# Altium schematic sheet photodiode-amplifier.SchDoc
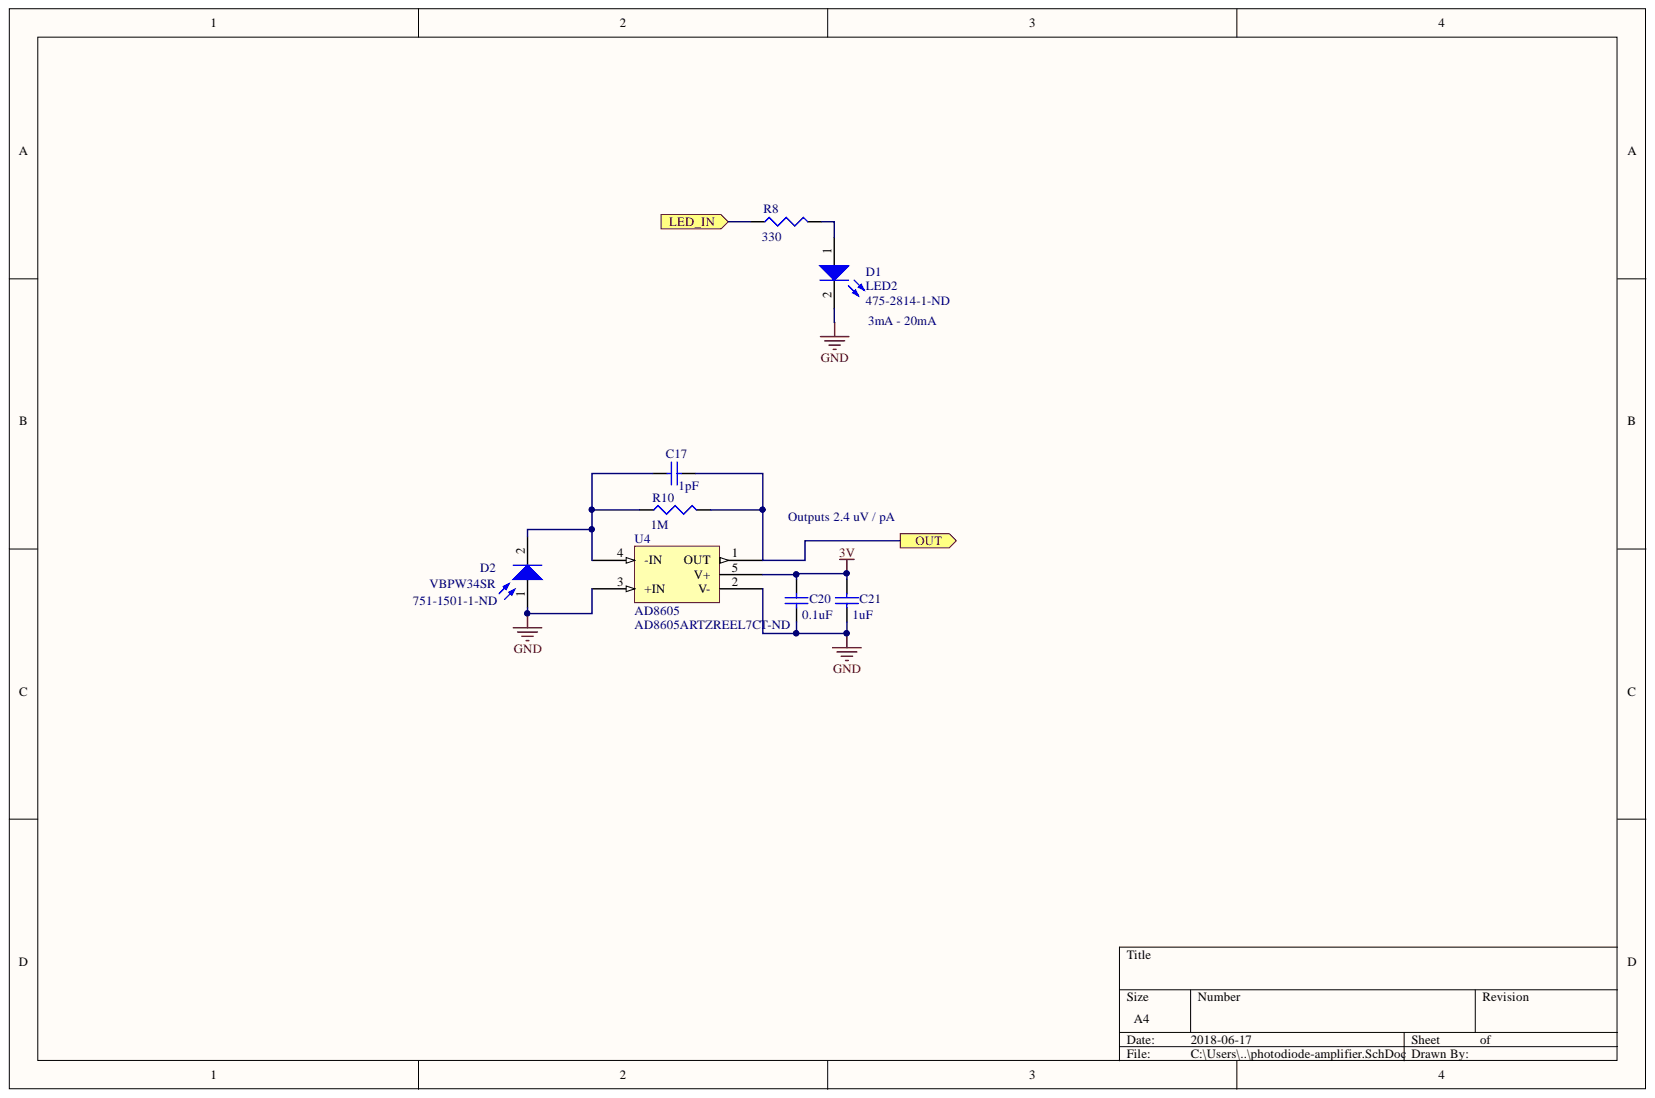
[diagram p0: photodiode-amplifier.SchDoc]

## Parts
C17  1pF  [0402]
C20  0.1uF  [0402]
C21  1uF  [1608[0603]]
D1  LED2  Supplier Part Number: 475-2814-1-ND  [LB P4SG]
D2  VBPW34SR  Supplier Part Number: 751-1501-1-ND  [VBPW34SR]
R8  330  [0402]
R10  1M  [J1-0603]
U4  AD8605  [SOP-65P200X125-5N]

## Ports
LED_IN, OUT

## Nets
3V: C20.2 C21.2 U4.5(V+)
GND: C20.1 C21.1 D1.2 D2.1 U4.2(V-) U4.3(+IN)
LED_IN: R8.1 ; port LED_IN
OUT: C17.2 R10.2 U4.1(OUT) ; port OUT
NetC17_1: C17.1 D2.2 R10.1 U4.4(-IN)
NetD1_1: D1.1 R8.2

## Library data of the parts
C17 C20 C21 = Cap Semi: Capacitor (Semiconductor SIM Model); Component Kind: Standard; LatestRevisionDate: 29-May-2009; LatestRevisionNote: IPC-7351 Footprint Added.; Library Name: Miscellaneous Devices.IntLib; PackageDescription: Chip Capacitor; PackageReference: C1206; Published: 8-Jun-2000; Signal Integrity: Cap Semi; Simulation: CAP; Supplier: Digi-Key; Publisher: Altium Limited
  C17: Value: 1pF
  C20: Value: 0.1uF
  C21: Value: 1uF
D1 = LED2: Typical RED, GREEN, YELLOW, AMBER GaAs LED; Component Kind: Standard; LatestRevisionDate: 17-Jul-2002; LatestRevisionNote: Re-released for DXP Platform.; Library Name: Miscellaneous Devices.IntLib; PackageDescription: SM LED; 2 Flat Leads; PackageReference: 3.2X1.6X1.1; PackageVersion: Feb-2002; Published: 8-Jun-2000; Simulation: LED2; Supplier: Digi-Key; Publisher: Altium Limited
D2 = Photo Sen: Photosensitive Diode; Component Kind: Standard; LatestRevisionDate: 17-Jul-2002; LatestRevisionNote: Re-released for DXP Platform.; Library Name: Miscellaneous Devices.IntLib; PackageReference: PIN2; Published: 24-Mar-1999; Supplier: Digi-Key; Publisher: Altium Limited
R8 R10 = Res3: Resistor; Component Kind: Standard; LatestRevisionDate: 29-May-2009; LatestRevisionNote: IPC-7351 Footprint Added.; Library Name: Miscellaneous Devices.IntLib; PackageDescription: Chip Resistor; PackageReference: J1-0603; Published: 8-Jun-2000; Signal Integrity: Res; Simulation: RESISTOR; Supplier: Digi-Key; Publisher: Altium Limited
  R8: Value: 330
  R10: Value: 1M
U4 = AD8605: Low noise, precision rail-to-rail op-amp.; Component Kind: Standard; Supplier: Digi-Key; Supplier 1: Digi-Key; Supplier Part Number 1: AD8605ARTZREEL7CT-ND; Library Name: PAY.SchLib
